# Altium schematic sheet OpenTag3.SchDoc(OpenTag3)
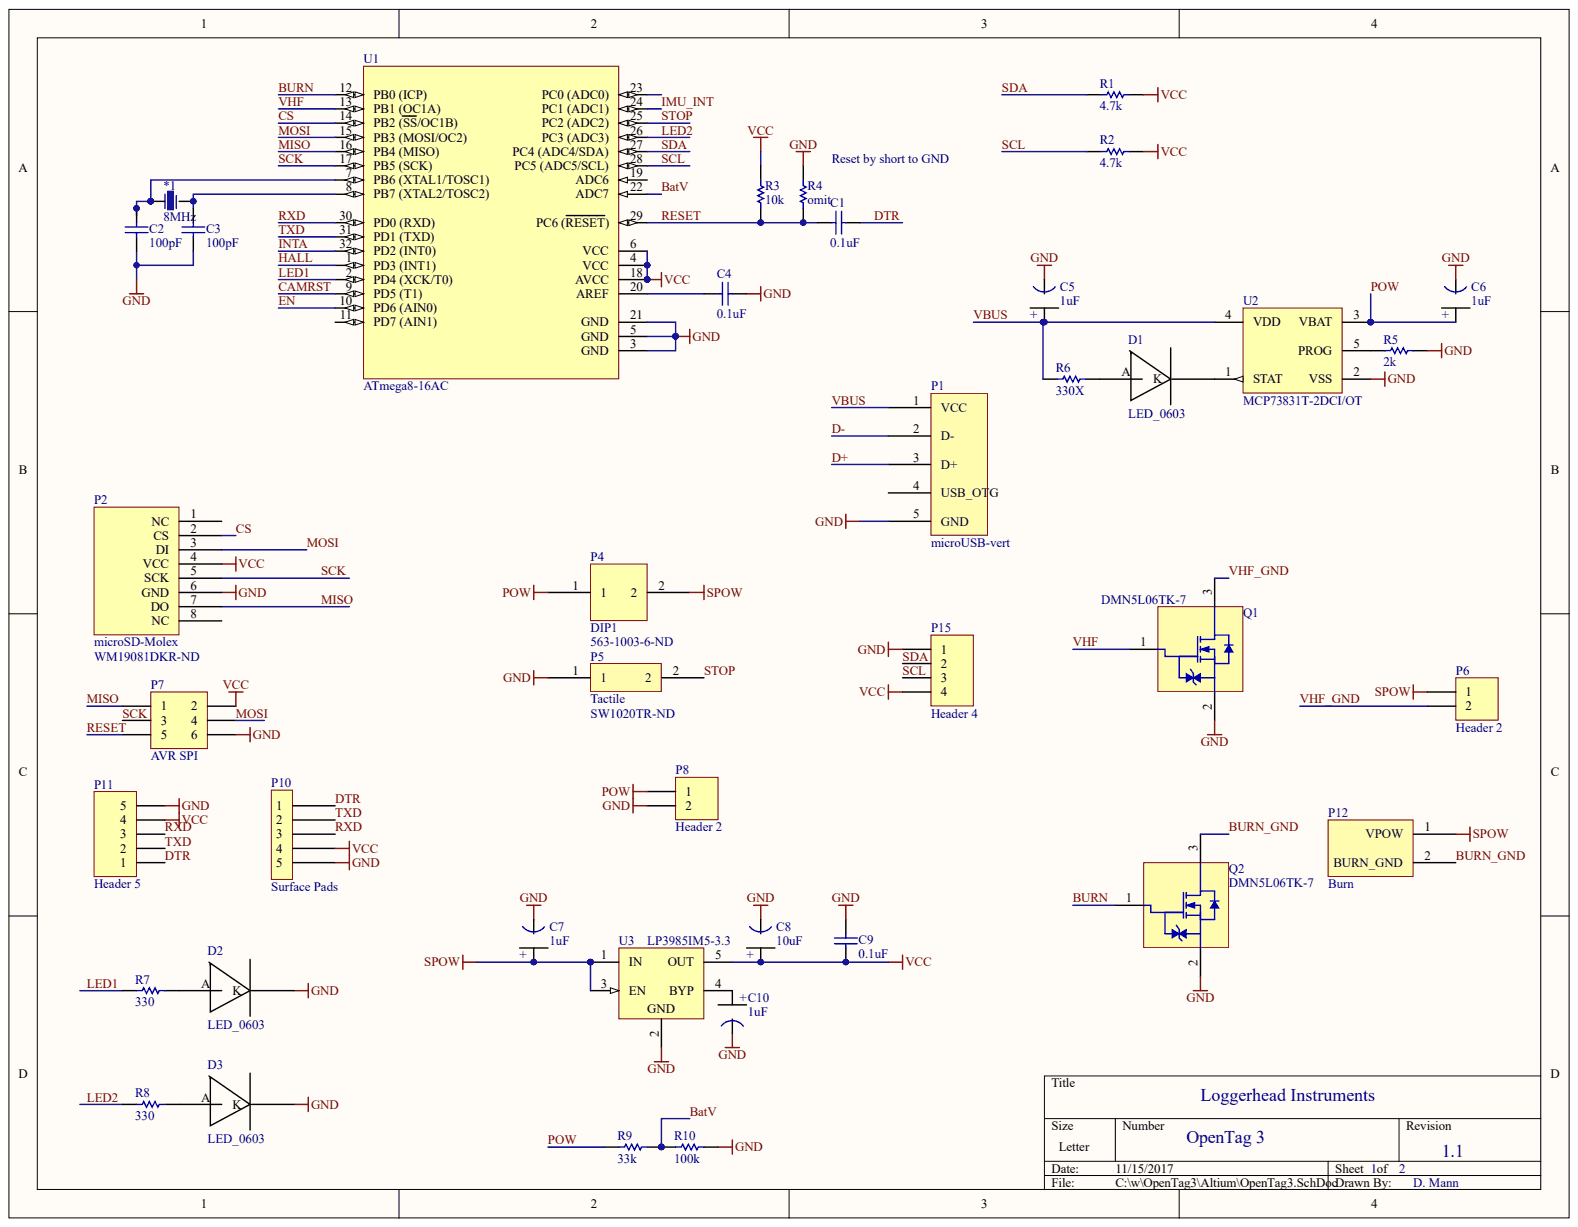
[diagram p0: OpenTag3.SchDoc]

## Parts
*1  8MHz
C1  0.1uF
C2  100pF
C3  100pF
C4  0.1uF
C5  1uF
C6  1uF
C7  1uF
C8  10uF
C9  0.1uF
C10  1uF
D1  LED_0603
D2  LED_0603
D3  LED_0603
P1  microUSB-vert
P2  microSD-Molex  Supplier Part Number 1: WM19081DKR-ND
P4  DIP1
P5  Tactile  Supplier Part Number 1: SW1020TR-ND
P6  Header 2
P7  AVR SPI
P8  Header 2
P10  Surface Pads
P11  Header 5
P12  Burn
P15  Header 4
Q1  DMN5L06TK-7
Q2  DMN5L06TK-7
R1  4.7k
R2  4.7k
R3  10k
R4  omit
R5  2k
R6  330X
R7  330
R8  330
R9  33k
R10  100k
U1  ATmega8-16AC
U2  MCP73831T-2DCI/OT
U3  LP3985IM5-3.3

## Nets
GND: C2.1 C3.1 C4.2 C5.1 C6.1 C7.1 C8.1 C9.2 C10.1 D2.K D3.K P1.5(GND) P2.6(GND) P5.1 P7.6 P8.2 P10.5 P11.5 P15.1 Q1.2 Q2.2 R4.2 R5.1 R10.1 U1.3(GND) U1.5(GND) U1.21(GND) U2.2(VSS) U3.2
SPOW: C7.2 P4.2 P6.1 P12.1 U3.1(IN) U3.3(EN)
VCC: C8.2 C9.1 P2.4(VCC) P7.2 P10.4 P11.4 P15.4 R1.2 R2.2 R3.2 U1.4(VCC) U1.6(VCC) U1.18(AVCC) U3.5(OUT)
BATV: R9.1 R10.2 U1.22(ADC7)
BURN: Q2.1 U1.12(PB0_(ICP))
BURN_GND: P12.2 Q2.3
CAMRST: U1.9(PD5_(T1))
CS: P2.2(CS) U1.14(PB2_(SS/OC1B))
D+: P1.3(D+)
D-: P1.2(D-)
DTR: C1.2 P10.1 P11.1
EN: U1.10(PD6_(AIN0))
HALL: U1.1(PD3_(INT1))
IMU_INT: U1.24(PC1_(ADC1))
INTA: U1.32(PD2_(INT0))
LED1: R7.1 U1.2(PD4_(XCK/T0))
LED2: R8.1 U1.26(PC3_(ADC3))
MISO: P2.7(DO) P7.1 U1.16(PB4_(MISO))
MOSI: P2.3(DI) P7.4 U1.15(PB3_(MOSI/OC2))
POW: C6.2 P4.1 P8.1 R9.2 U2.3(VBAT)
RESET: C1.1 P7.5 R3.1 R4.1 U1.29(PC6_(RESET))
RXD: P10.3 P11.3 U1.30(PD0_(RXD))
SCK: P2.5(SCK) P7.3 U1.17(PB5_(SCK))
SCL: P15.3 R2.1 U1.28(PC5_(ADC5/SCL))
SDA: P15.2 R1.1 U1.27(PC4_(ADC4/SDA))
STOP: P5.2 U1.25(PC2_(ADC2))
TXD: P10.2 P11.2 U1.31(PD1_(TXD))
VBUS: C5.2 P1.1(VCC) R6.1 U2.4(VDD)
VHF: Q1.1 U1.13(PB1_(OC1A))
VHF_GND: P6.2 Q1.3
Net*1_1: *1.1 C2.2 U1.7(PB6_(XTAL1/TOSC1))
Net*1_2: *1.2 C3.2 U1.8(PB7_(XTAL2/TOSC2))
NetC4_1: C4.1 U1.20(AREF)
NetC10_2: C10.2 U3.4(BYP)
NetD1_A: D1.A R6.2
NetD1_K: D1.K U2.1(STAT)
NetD2_A: D2.A R7.2
NetD3_A: D3.A R8.2
NetR5_2: R5.2 U2.5(PROG)

Not connected: P1 4; P2 1(NC) 8(NC); U1 11(PD7_(AIN1)) 19(ADC6) 23(PC0_(ADC0))

## Library data of the parts
C1-C4 C9 = (no symbol name): Note: Footprint corresponds to dimensions for bulk cassette packaging. This case size has tightened tolerances.; Published: 17-Jul-2002; LatestRevisionDate: 19-Mar-2004; LatestRevisionNote: Silkscreen size reduced.; DatasheetDocument: Mar-2001; PackageDocument: Mar-2001; PackageReference: 0402; Code_JEITA: 0402; Code_IPC: 1005 [0402]; ComponentLink1Description: Manufacturer Link; ComponentLink1URL: http://www.kemet.com/; ComponentLink2Description: Datasheet; ComponentLink2URL: http://www.kemet.com/kemet/web/homepage/kechome.nsf/vapubfilesname/F3102TA.pdf/$file/F3102TA.pdf; Publisher: Altium Limited
  C1 C4 C9: Value: 0.1uF
  C2 C3: Value: 100pF
Q1 Q2 = (no symbol name): Fabrication Tested: NO; Supplier 1: Digi-Key; Supplier Part Number 1: DMN5L06TKDICT-ND; HelpURL: http://www.diodes.com/datasheets/ds30926.pdf; Creator and Date: Will Flanery 2010-09-02; Footprint Verified By: *; Schematic Verified By: *; Vendor Info Verified By: *
U3 = (no symbol name): Manufacturer: National Semiconductor; CompType: LDO IC; PackageTopMarking: LDQB*XTT; PowerWise: N; Published: 4-Dec-2008; DatasheetVersion: Aug-2005; PackageVersion: revD, Aug-2008; PackageReference: MF05A; PackageDescription: Molded SOT-23, .115 x .063 x .040 in Body, 5 Lead, .0375 in Pitch; PartNumber: LP3985IM5-3.3; RoHS: O; PinCount: 5; DigiKeyPartNumber: LP3985IM5-3.3CT-ND; ComponentLink1Description: LP3985 Datasheet; ComponentLink1URL: http://www.national.com/ds/LP/LP3985.pdf; ComponentLink2Description: LP3985 Web Page; ComponentLink2URL: http://www.national.com/pf/LP/LP3985.html; ComponentLink3Description: MF05A Package Page; ComponentLink3URL: http://www.national.com/packaging/folders/mf05a.html; Publisher: Altium Limited
*1 C5-C8 C10 D1-D3 P1 P2 P4-P8 P10-P12 P15 R1-R10 U1 U2 = (no symbol name)
  C5 C6: Value: *
  C7 C10: Value: 1uF
  C8: Value: 10uF
  P2 P5: Supplier 1: Digi-Key
  P4: Supplier 1: Digi-Key; Supplier Part Number 1: 563-1003-6-ND
  P6-P8 P11 P15: LatestRevisionDate: 17-Jul-2002; LatestRevisionNote: Re-released for DXP Platform.
  R1-R10: Published: 17-Dec-2003; LatestRevisionDate: 19-Mar-2004; LatestRevisionNote: Silkscreen size reduced.; PackageDocument: Mar-2003; PackageReference: 3-0603; Code_IPC: 1608 [0603]; ComponentLink1Description: Manufacturer Link; ComponentLink1URL: http://www.panasonic.com/industrial/components/resistive_products.htm; ComponentLink2Description: Datasheet; ComponentLink2URL: http://www.panasonic.com/industrial/components/pdf/AOA0000CE2.pdf
  U1: Published: 17-Sep-2003; LatestRevisionDate: 13-Apr-2006; LatestRevisionNote: IPC-7351 Footprint Added.; DatasheetVersion: 2486H-AVR-09/02; PackageVersion: revB, Oct-2001; PackageReference: 32A; PackageDescription: 32-Pin Thin Quad Flat Pack 0.8 mm Pitch; Code_JEDEC: MS-026-ABA; ComponentLink1Description: Manufacturer Link; ComponentLink1URL: http://www.atmel.com; ComponentLink2Description: Datasheet; ComponentLink2URL: http://www.atmel.com/dyn/resources/prod_documents/doc2486.pdf
  U2: DatasheetVersion: revE, Sep-2008; PackageVersion: revBB, Aug-2009; PackageReference: SOT-23-OT5; PackageDescription: 5-Lead Plastic Small Outline Transistor (OT) [SOT-23]; Code_IPC: SOT23-5; ComponentLink1Description: Manufacturer URL; ComponentLink1URL: http://www.microchip.com/; ComponentLink2Description: Datasheet; ComponentLink2URL: http://ww1.microchip.com/downloads/en/DeviceDoc/21984e.pdf; ComponentLink3Description: Package Specification; ComponentLink3URL: http://www.microchip.com/stellent/groups/techpub_sg/documents/packagingspec/en012702.pdf
  P6-P8 P11 P15 R1-R10 U1: Publisher: Altium Limited
